# Altium schematic sheet ID-Serial.SchDoc(ID-Serial)
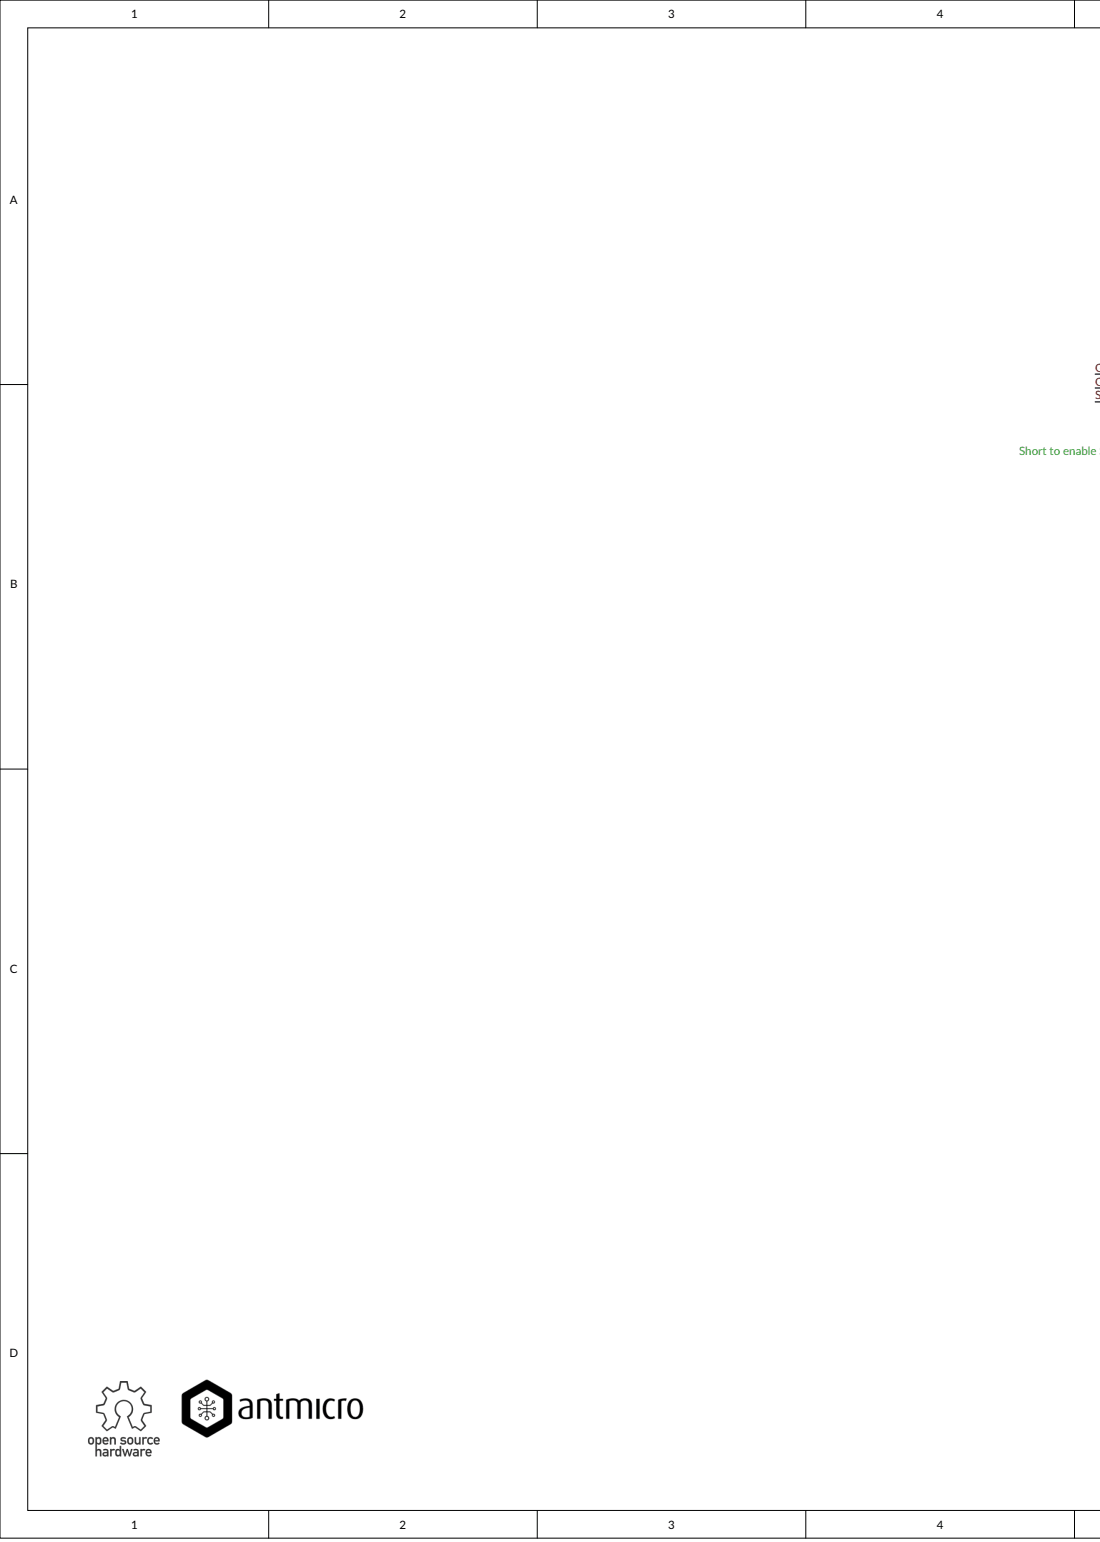
[diagram p0: ID-Serial.SchDoc, left]
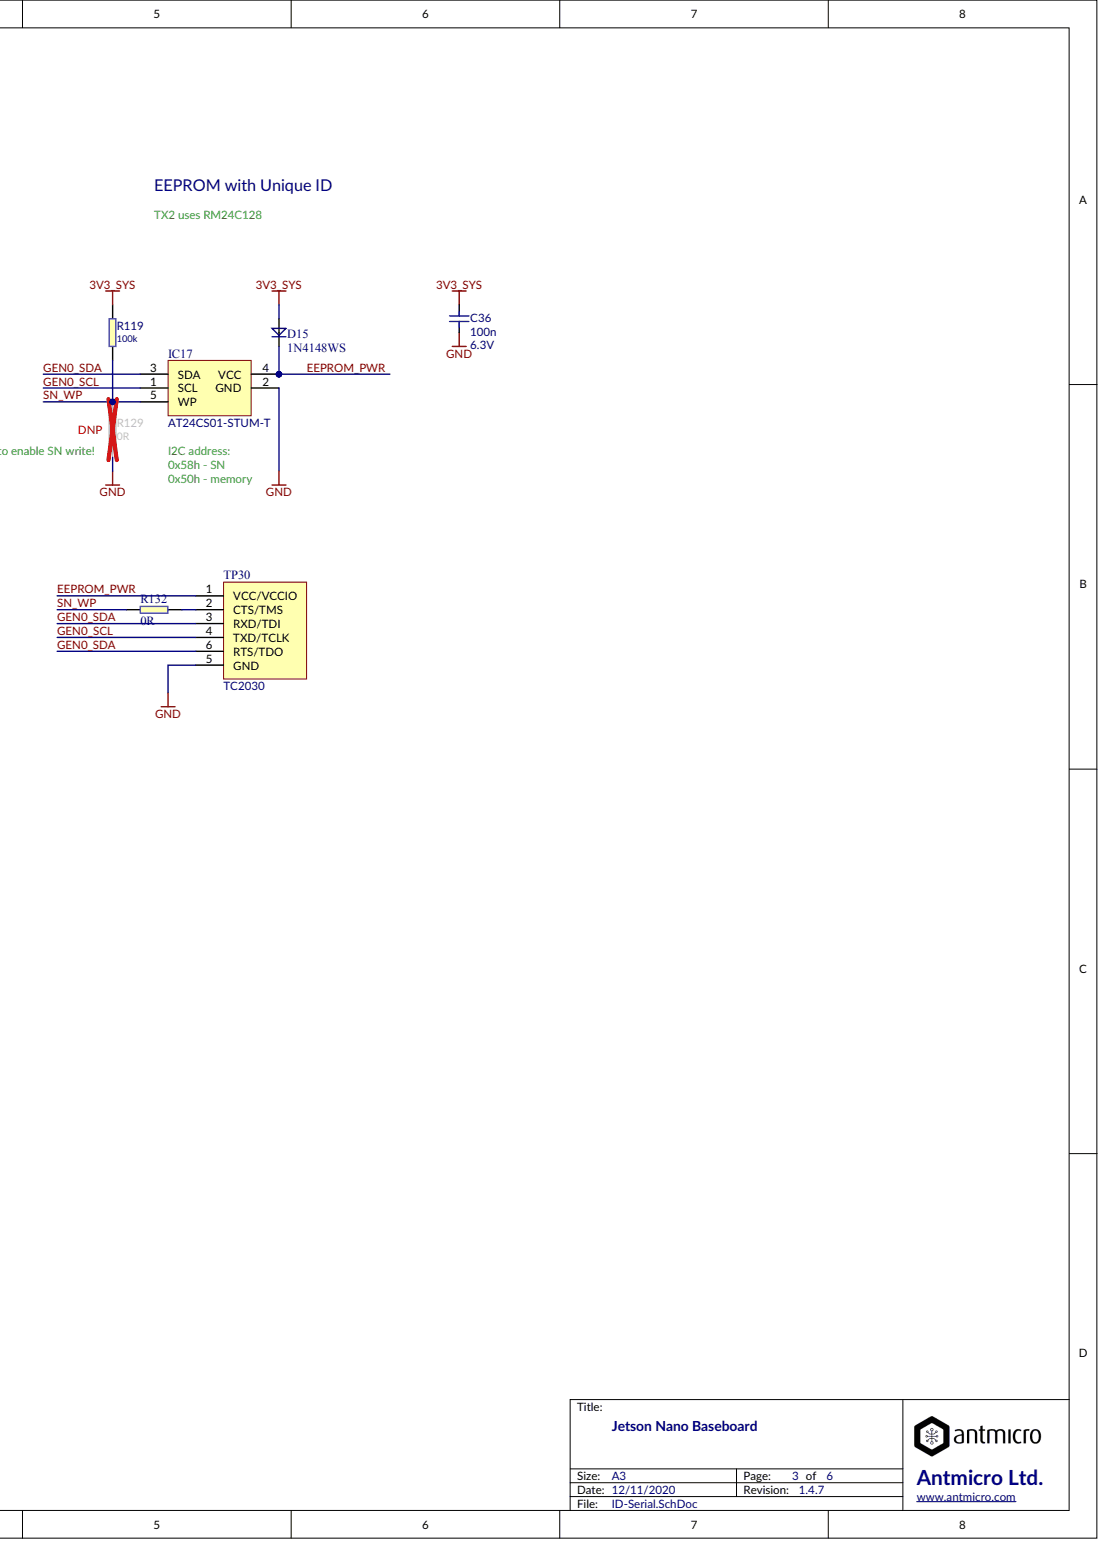
[diagram p1: ID-Serial.SchDoc, right]

## Parts
C36  Voltage: 6.3V  (hidden comment: 100n)
D15  1N4148WS
IC17  AT24CS01-STUM-T
R119  100k
R129  0R
R132  0R
TP30  TC2030

## Nets
3V3_SYS: C36.2 D15.A R119.1
GND: C36.1 IC17.2(GND) R129.1 TP30.5(GND)
EEPROM_PWR: D15.C IC17.4(VCC) TP30.1(VCC/VCCIO)
GEN0_SCL: IC17.1(SCL) TP30.4(TXD/TCLK)
GEN0_SDA: IC17.3(SDA) TP30.3(RXD/TDI) TP30.6(RTS/TDO)
SN_WP: IC17.5(WP) R119.2 R129.2 R132.1
NetR132_2: R132.2 TP30.2(CTS/TMS)

## Library data of the parts
C36 = (no symbol name): Manufacturer: TDK; PartNumber: C_100n_0402; MPN: C1005X5R0J104K050BA; Value: 100n
D15 = (no symbol name): Manufacturer: ON SEMICONDUCTOR; PartNumber: 1N4148WS; Value: 1N4148WS; MPN: 1N4148WS
IC17 = (no symbol name): Manufacturer: Microchip; PartNumber: AT24CS01-STUM-T; Value: AT24CS01-STUM-T; MPN: AT24CS01-STUM-T
R119 = (no symbol name): PartNumber: R_100k_0402; Manufacturer: VISHAY; MPN: CRCW0402100KFKEDHP; Value: 100k
R129 R132 = (no symbol name): PartNumber: R_0R_0402; Manufacturer: Panasonic; MPN: ERJ2GE0R00X; Value: 0R
TP30 = (no symbol name): Manufacturer: Tag-Connect; PartNumber: TC2030; Value: TC2030; MPN: TC2030
